# Altium schematic sheet Ethernet.SchDoc(Ethernet)
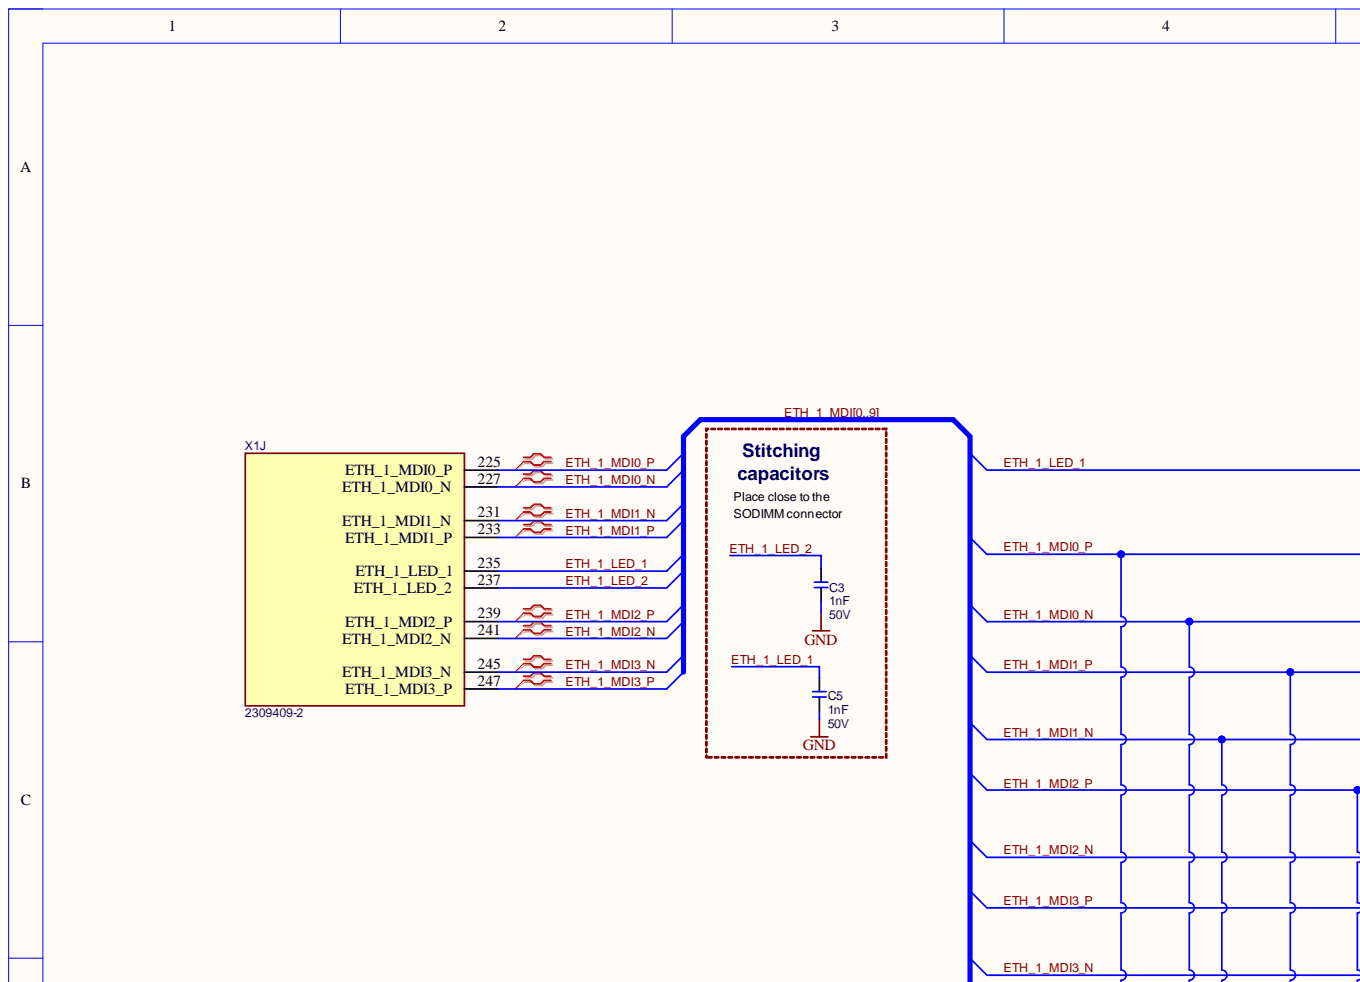
[diagram p0: Ethernet.SchDoc, top left]
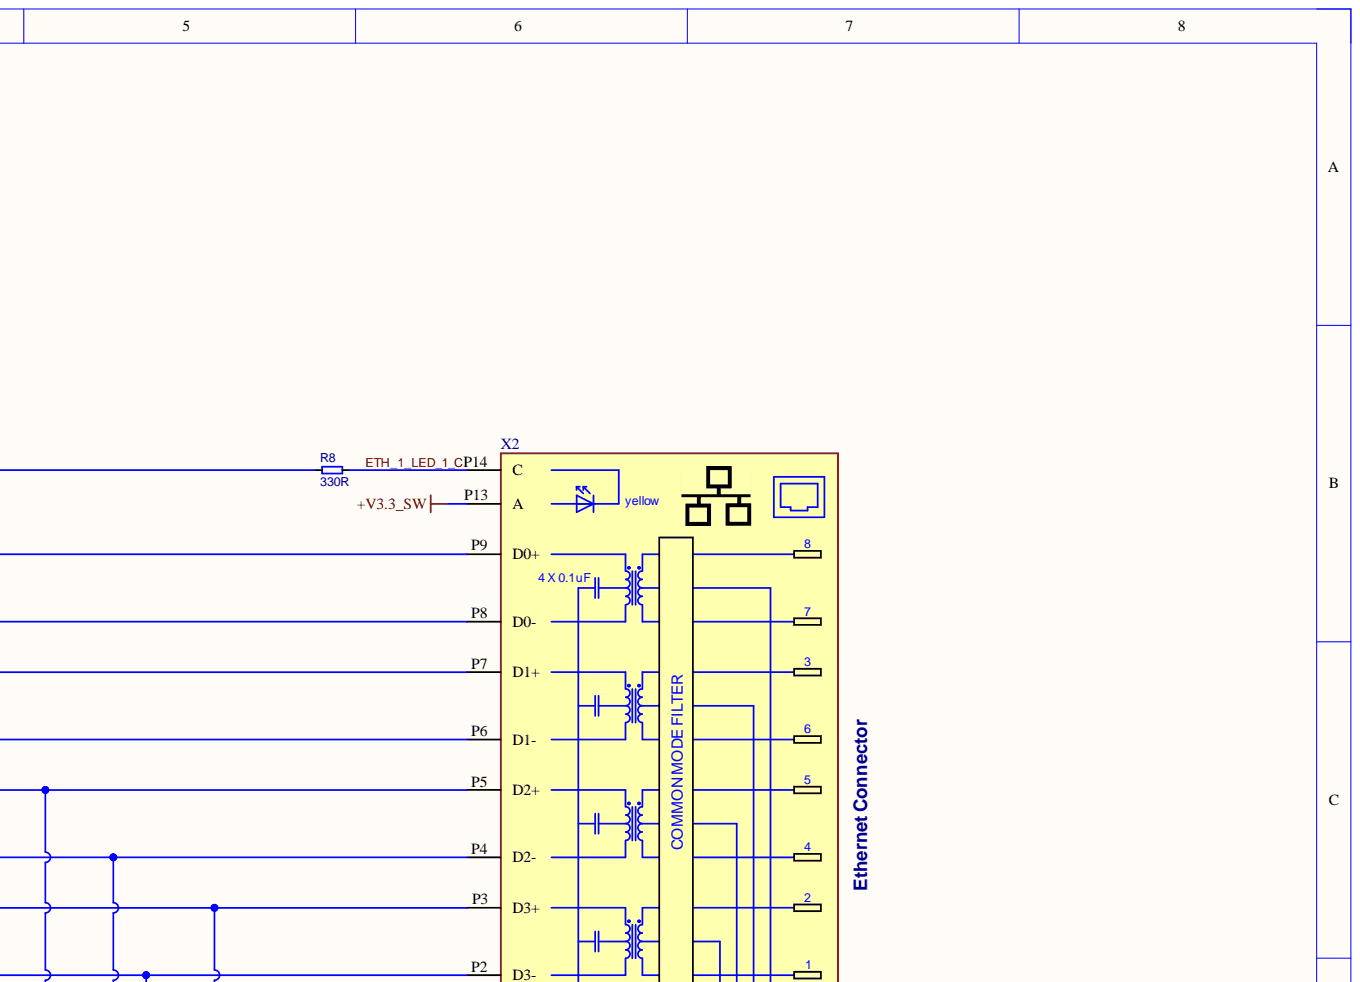
[diagram p1: Ethernet.SchDoc, top right]
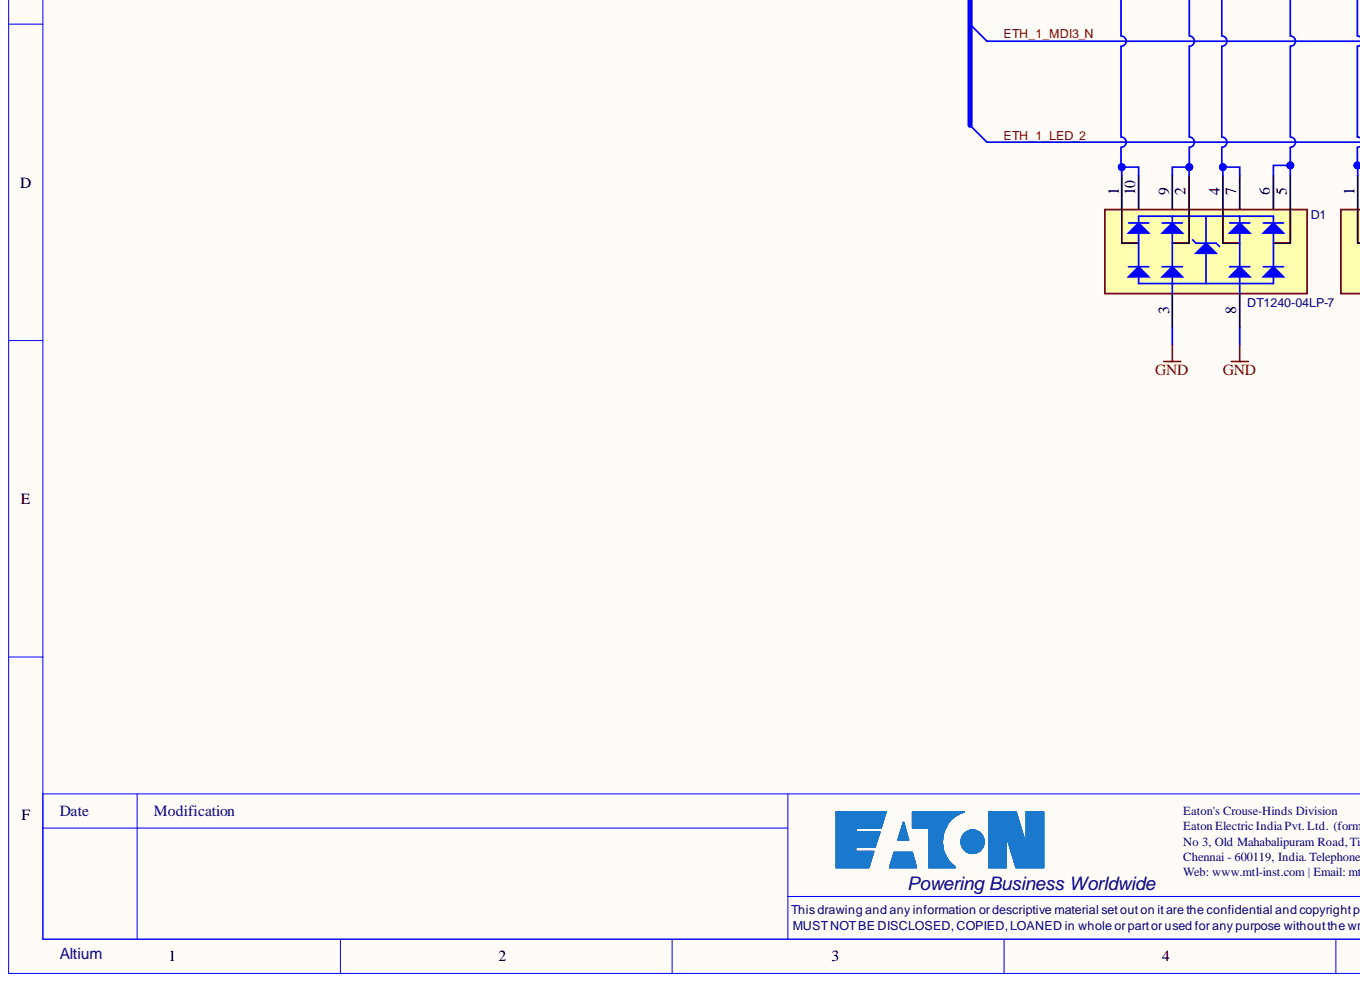
[diagram p2: Ethernet.SchDoc, bottom left]
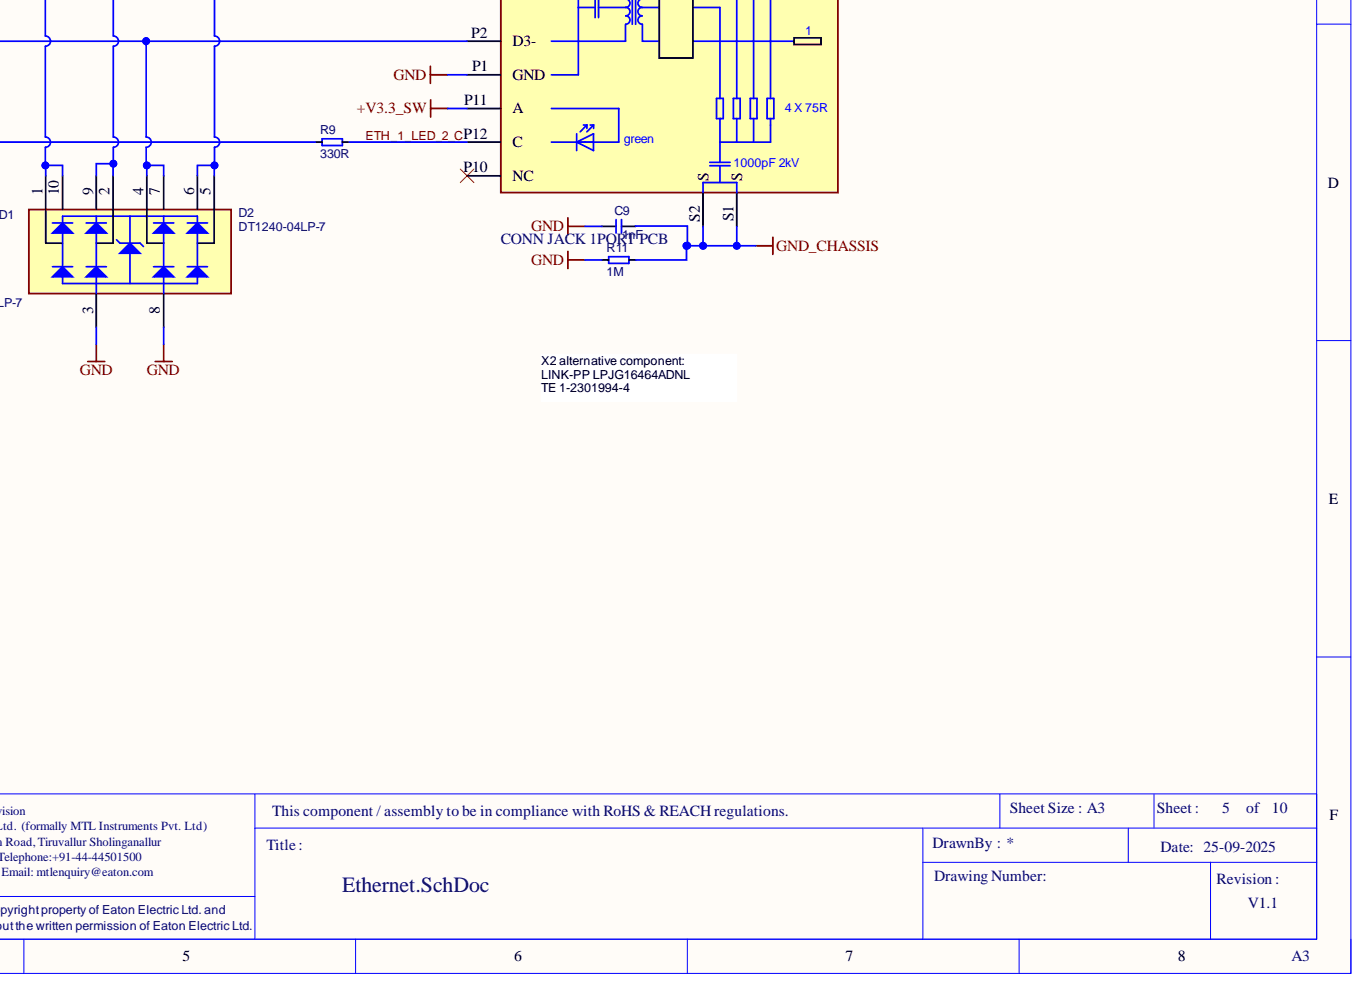
[diagram p3: Ethernet.SchDoc, bottom right]

## Parts
C3  1nF  Rating: 50V  [CAPC1005X60N]
C5  1nF  Rating: 50V  [CAPC1005X60N]
C9  1nF  [T_CAPC1206X180N]
D1  DT1240-04LP-7  [D-DI-DT1240-04LP-7]
D2  DT1240-04LP-7  [D-DI-DT1240-04LP-7]
R8  330R  [RESC1005X40N]
R9  330R  [RESC1005X40N]
R11  1M  [RESC3216X75N]
X1  2309409-2  [CON-TE-2309409-2]  (part J)
X2  CONN JACK 1PORT PCB  [Con-Pulse-JX3V-9015NL]

## Nets
+V3.3_SW: X2.P11(A) X2.P13(A)
GND: C3.1 C5.1 C9.1 D1.3 D1.8 D2.3 D2.8 R11.1 X2.P1(GND)
GND_CHASSIS: C9.2 R11.2 X2.S1(S) X2.S2(S)
ETH_1_LED_1: C5.2 R8.1 X1.235(ETH_1_LED_1)
ETH_1_LED_1_C: R8.2 X2.P14(C)
ETH_1_LED_2: C3.2 R9.1 X1.237(ETH_1_LED_2)
ETH_1_LED_2_C: R9.2 X2.P12(C)
ETH_1_MDI0_N: D1.2 D1.9 X1.227(ETH_1_MDI0_N) X2.P8(D0-)
ETH_1_MDI0_P: D1.1 D1.10 X1.225(ETH_1_MDI0_P) X2.P9(D0+)
ETH_1_MDI1_N: D1.4 D1.7 X1.231(ETH_1_MDI1_N) X2.P6(D1-)
ETH_1_MDI1_P: D1.5 D1.6 X1.233(ETH_1_MDI1_P) X2.P7(D1+)
ETH_1_MDI2_N: D2.2 D2.9 X1.241(ETH_1_MDI2_N) X2.P4(D2-)
ETH_1_MDI2_P: D2.1 D2.10 X1.239(ETH_1_MDI2_P) X2.P5(D2+)
ETH_1_MDI3_N: D2.4 D2.7 X1.245(ETH_1_MDI3_N) X2.P2(D3-)
ETH_1_MDI3_P: D2.5 D2.6 X1.247(ETH_1_MDI3_P) X2.P3(D3+)
ETH_1_MDI0:  [also labelled ETH_1_MDI]
ETH_1_MDI1:  [also labelled ETH_1_MDI]
ETH_1_MDI2:  [also labelled ETH_1_MDI]
ETH_1_MDI3:  [also labelled ETH_1_MDI]
ETH_1_MDI4:  [also labelled ETH_1_MDI]
ETH_1_MDI5:  [also labelled ETH_1_MDI]
ETH_1_MDI6:  [also labelled ETH_1_MDI]
ETH_1_MDI7:  [also labelled ETH_1_MDI]
ETH_1_MDI8:  [also labelled ETH_1_MDI]
ETH_1_MDI9:  [also labelled ETH_1_MDI]

Not connected: X2 P10(NC)

## Library data of the parts
C3 C5 = C-X7R-1nF-50V-10%-0402: Capacitor Ceramic X7R 1nF 50V 10% 0402; Manufacturer Name: KEMET; Manufacturer Part No.: C0402C102K5RECTU; Component Kind: Standard; Library Name: SoM_Camera_Encoder.SCHLIB; Tolerance: 10%; Type: X7R; Value: 1nF
C9 = C-X7R-1nF-2KV-10%-1206: Capacitor Ceramic X7R 1nF 2KV 10% 1206; Manufacturer Name: KEMET; Manufacturer Part No.: C1206C102KGRACTM; Component Kind: Standard; Library Name: SoM_Camera_Encoder.SCHLIB; Rating: 2KV; Tolerance: 10%; Type: X7R; Value: 1nF
D1 D2 = D-DI-DT1240-04LP-7: 4 CHANNEL LOW CAPACITANCE TVS DIODE ARRAY; Manufacturer Name: Diodes; Component Kind: Standard; Manufacturer Part No.: DT1240-04LP-7; Library Name: Mallow.SchLib
R8 R9 = R-330R-63mW-5%-0402: Resistor 330 ohm 63mW 5% 0402; Manufacturer Name: Stackpole Electronics Inc; Manufacturer Part No.: RMCF0402JT330R; Component Kind: Standard; Library Name: SoM_Camera_Encoder.SCHLIB; Rating: 63mW; Tolerance: 5%; Value: 330R
R11 = R-1M-250mW-5%-1206: Resistor 1 Mohm 250mW 5% 1206; Manufacturer Name: YAGEO; Manufacturer Part No.: RC1206JR-071ML; Component Kind: Standard; Library Name: SoM_Camera_Encoder.SCHLIB; Rating: 250mW; Tolerance: 5%; Value: 1M
X1 = CON-TE-2309409-2: DDR4 SODIMM Socket, 260 pins, 5.2mm(H), 0.5mm Pitch; Manufacturer Name: TE Connectivity; Component Kind: Standard; Manufacturer Part No.: 2309409-2; Package: DDR4-SODIMM-260; Temperature Range: -40C to +125C; Library Name: Mallow.SchLib
X2 = CON-PULSE-JX3V-9015NL: 1 Port RJ45 Through Hole 10/100/1000 Base-T, AutoMDIX; Manufacturer Name: Pulse Electronics; Component Kind: Standard; Library Name: SoM_Camera_Encoder.SCHLIB; Manufacturer Part No.: JX3V-9015NL
